# Altium schematic sheet Top.SchDoc(Top)
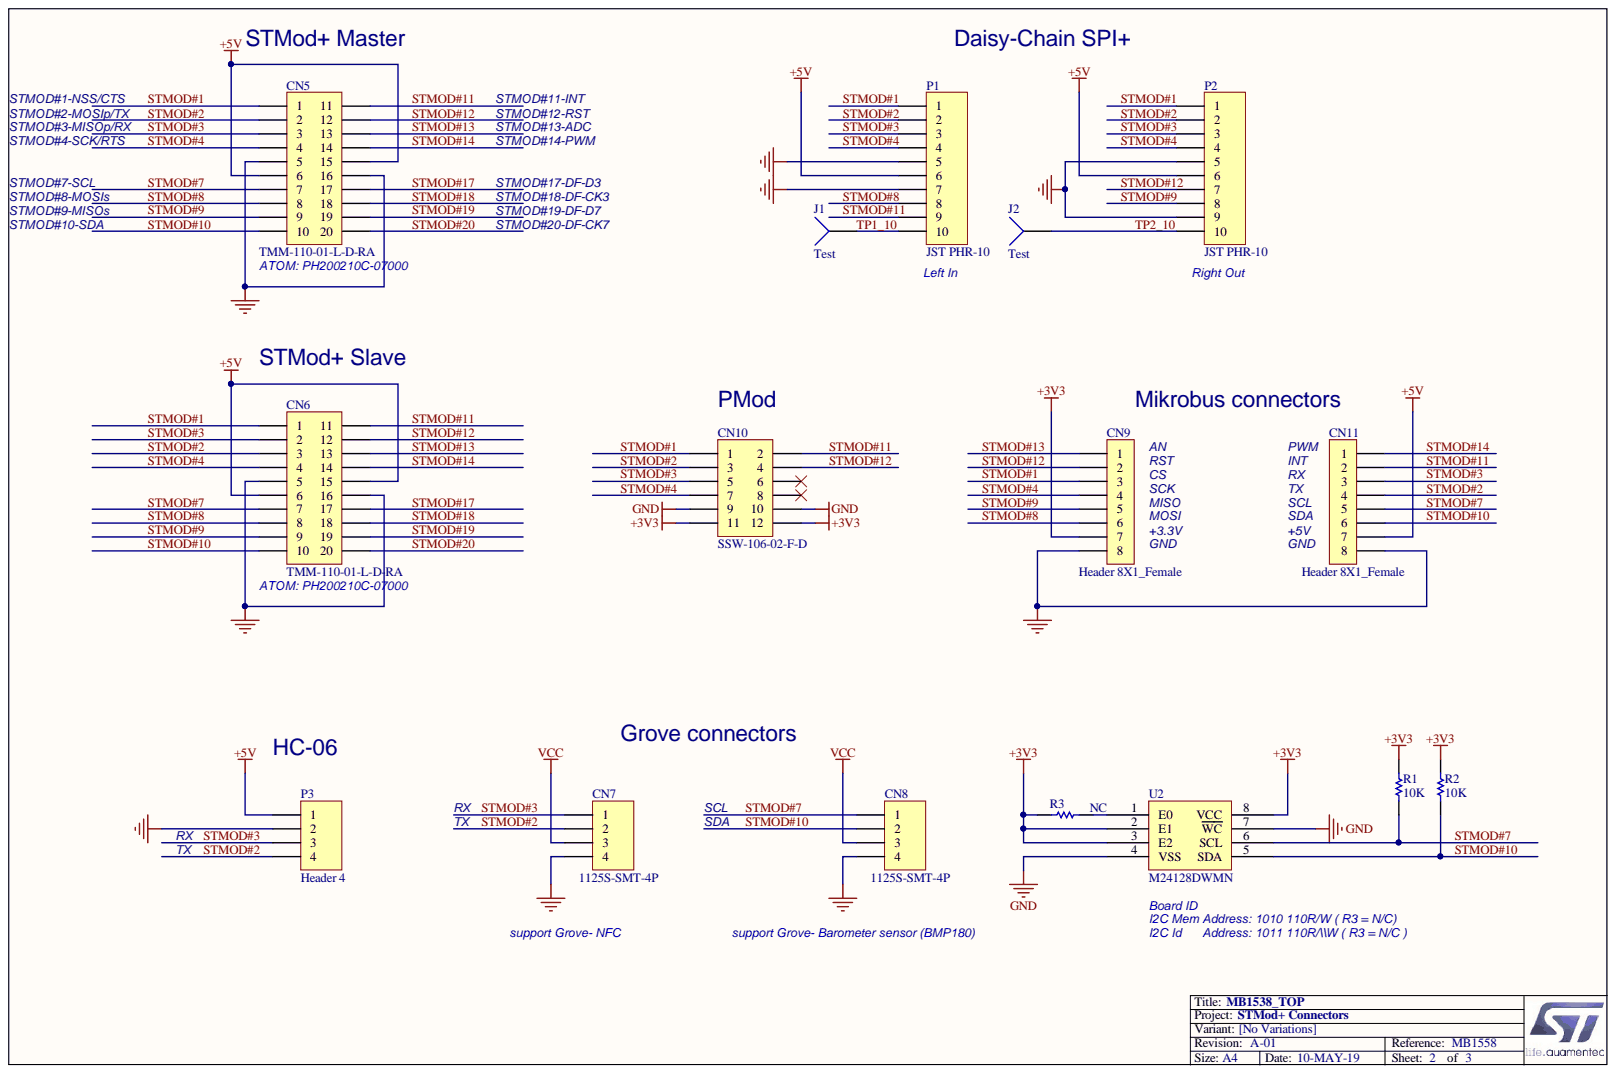
[diagram p0: Top.SchDoc]

## Parts
CN5  TMM-110-01-L-D-RA  [CON_SAMTEC_TMM-110-01-L-D-RA__STMOD+]
CN6  TMM-110-01-L-D-RA  [CON_SAMTEC_TMM-110-01-L-D-RA__STMOD+]
CN7  1125S-SMT-4P  [GROVE_4PTS-NO-MARK]
CN8  1125S-SMT-4P  [GROVE_4PTS-NO-MARK]
CN9  Header 8X1_Female  [1*8P_FEMALE]
CN10  SSW-106-02-F-D  [HDR2X6]
CN11  Header 8X1_Female  [1*8P_FEMALE]
J1  Test  [PIN1]
J2  Test  [PIN1]
P1  JST PHR-10  [B10B-PH-K-S]
P2  JST PHR-10  [B10B-PH-K-S]
P3  Header 4  [HE-06]
R1  value 10K  [R0402]  (hidden comment: Resistor)
R2  value 10K  [R0402]  (hidden comment: Resistor)
R3  value NC  [R0402]  (hidden comment: Resistor)
U2  M24128DWMN  [ICC_SO8_5X4_P127]

## Nets
+3V3: CN9.7 CN10.11 CN10.12 R1.2 R2.2 R3.2 U2.2(E1) U2.3(E2) U2.8(VCC)
+5V: CN5.6 CN5.15 CN6.6 CN6.15 CN11.7 P1.6 P2.6 P3.1
GND: CN5.5 CN5.16 CN6.5 CN6.16 CN7.4 CN8.4 CN9.8 CN10.9 CN10.10 CN11.8 P1.5 P1.7 P2.5 P2.9 P3.2 U2.4(VSS) U2.7(WC)
VCC: CN7.3 CN8.3
STMOD#1: CN5.1 CN6.1 CN9.3 CN10.1 P1.1 P2.1
STMOD#2: CN5.2 CN6.3 CN7.2 CN10.3 CN11.4 P1.2 P2.2 P3.4
STMOD#3: CN5.3 CN6.2 CN7.1 CN10.5 CN11.3 P1.3 P2.3 P3.3
STMOD#4: CN5.4 CN6.4 CN9.4 CN10.7 P1.4 P2.4
STMOD#7: CN5.7 CN6.7 CN8.1 CN11.5 R1.1 U2.6(SCL)
STMOD#8: CN5.8 CN6.8 CN9.6 P1.8
STMOD#9: CN5.9 CN6.9 CN9.5 P2.8
STMOD#10: CN5.10 CN6.10 CN8.2 CN11.6 R2.1 U2.5(SDA)
STMOD#11: CN5.11 CN6.11 CN10.2 CN11.2 P1.9
STMOD#12: CN5.12 CN6.12 CN9.2 CN10.4 P2.7
STMOD#13: CN5.13 CN6.13 CN9.1
STMOD#14: CN5.14 CN6.14 CN11.1
STMOD#17: CN5.17 CN6.17
STMOD#18: CN5.18 CN6.18
STMOD#19: CN5.19 CN6.19
STMOD#20: CN5.20 CN6.20
TP1_10: J1.1 P1.10
TP2_10: J2.1 P2.10
NetR3_1: R3.1 U2.1(E0)

Not connected: CN10 6 8

## Library data of the parts
CN5 CN6 = Header 10X2A: Header, 10-Pin, Dual row; Signal Integrity: Connector; Library Name: Miscellaneous Connectors.IntLib; LatestRevisionNote: Re-released for DXP Platform.; LatestRevisionDate: 17-Jul-2002; Component Kind: Standard; Publisher: Altium Limited
CN7 CN8 = Header 4: Signal Integrity: Connector; Library Name: Miscellaneous Connectors.IntLib; LatestRevisionNote: Re-released for DXP Platform.; LatestRevisionDate: 17-Jul-2002; Description: 1125S-SMT-4P; Component Kind: Standard; Publisher: Altium Limited
CN9 CN11 = Header 8X1_Female: Header, 8-Pin, Single row,female; Ordered by: Subcon; ECCN_US/EU: NA; Signal Integrity: Connector; Library Name: Nucleo_64.SCHLIB; LatestRevisionNote: Re-released for DXP Platform.; LatestRevisionDate: 17-Jul-2002; Component Kind: Standard; Publisher: Altium Limited
CN10 = Header 6X2: Header, 6-Pin, Dual row; Signal Integrity: Connector; Library Name: Miscellaneous Connectors.IntLib; LatestRevisionNote: Re-released for DXP Platform.; LatestRevisionDate: 17-Jul-2002; Component Kind: Standard; Publisher: Altium Limited
J1 J2 = Socket: Signal Integrity: Connector; Library Name: Miscellaneous Connectors.IntLib; LatestRevisionNote: Re-released for DXP Platform.; LatestRevisionDate: 17-Jul-2002; Description: Socket; Component Kind: Standard; Publisher: Altium Limited
P1 P2 = Header 10: Header, 10-Pin; Signal Integrity: Connector; Library Name: Miscellaneous Connectors.IntLib; LatestRevisionNote: Re-released for DXP Platform.; LatestRevisionDate: 17-Jul-2002; Component Kind: Standard; Publisher: Altium Limited
P3 = Header 4: Header, 4-Pin; Signal Integrity: Connector; Library Name: Miscellaneous Connectors.IntLib; LatestRevisionNote: Re-released for DXP Platform.; LatestRevisionDate: 17-Jul-2002; Component Kind: Standard; Publisher: Altium Limited
R1-R3 = MCD-00053: Resistor,10K,0402,1%; Manufacturer_Part_Number: RC0402FR-0710KL; Manufacturer: YAGEO; Provided_By: subcon; Database Table Name: MCD_PARTS$; PartType: Resistors; Library Name: MCD_PARTS_database.DbLib; Internal_Part_Number: MCD-00053; ECCN_US: n/a; ECCN_EU: n/a; ComponentLink1Description: Yageo_Resistor_RC0402-series.pdf; Component Kind: Standard
U2 = MCD-00293: I2C memory; Provided_By: ST; Database Table Name: MCD_PARTS$; Value: M24128DWMN; PartType: ICS; Manufacturer_Part_Number: M24128DWMN; Manufacturer: ST; Library Name: MCD_PARTS_database.DbLib; Internal_Part_Number: MCD-00293; ComponentLink1Description: M24128DWMN.pdf; Component Kind: Standard
